# Altium schematic sheet Motor Driver.SchDoc("Motor Driver")
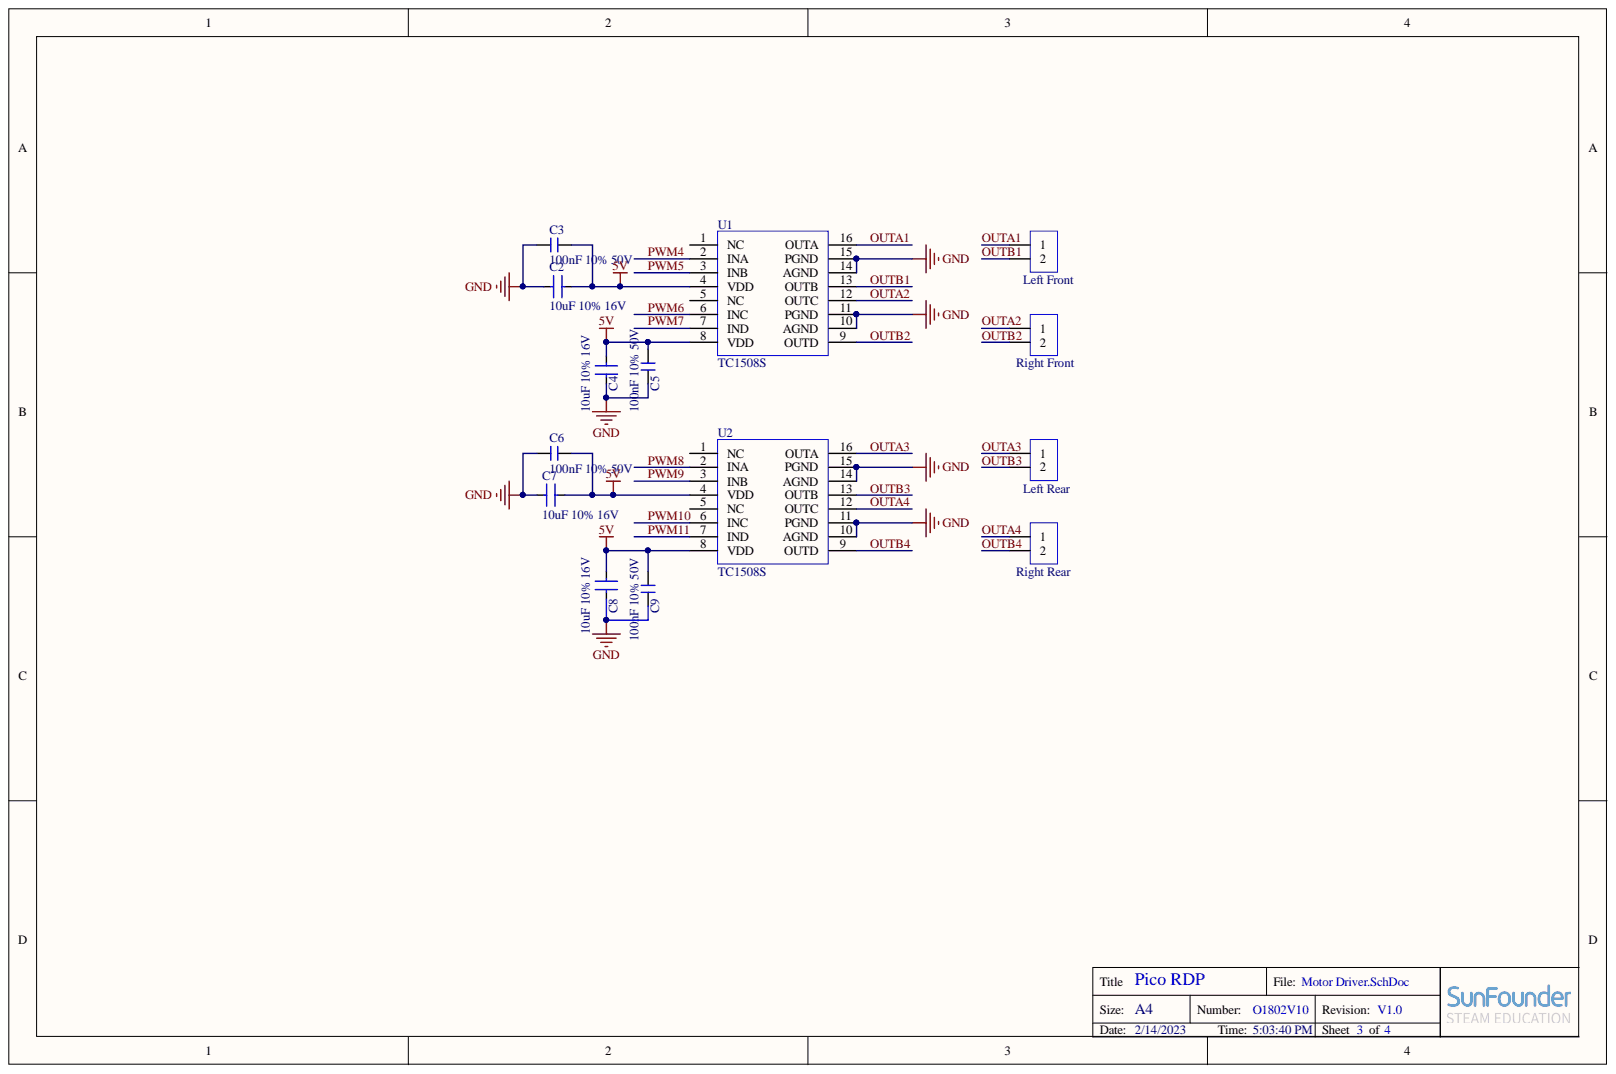
[diagram p0: Motor Driver.SchDoc]

## Parts
C2  10uF 10% 16V  [电容-0805]
C3  100nF 10% 50V  [电容-0603]
C4  10uF 10% 16V  [电容-0805]
C5  100nF 10% 50V  [电容-0603]
C6  100nF 10% 50V  [电容-0603]
C7  10uF 10% 16V  [电容-0805]
C8  10uF 10% 16V  [电容-0805]
C9  100nF 10% 50V  [电容-0603]
Left_Front  XH2.54 2P 直插 立式  [XH2.54 2P 直插 立式]  (value not drawn)
Left_Rear  XH2.54 2P 直插 立式  [XH2.54 2P 直插 立式]  (value not drawn)
Right_Front  XH2.54 2P 直插 立式  [XH2.54 2P 直插 立式]  (value not drawn)
Right_Rear  XH2.54 2P 直插 立式  [XH2.54 2P 直插 立式]  (value not drawn)
U1  TC1508S  [SOP16]
U2  TC1508S  [SOP16]

## Nets
5V: C2.1 C3.1 C4.2 C5.2 C6.1 C7.1 C8.2 C9.2 U1.4(VDD) U1.8(VDD) U2.4(VDD) U2.8(VDD)
GND: C2.2 C3.2 C4.1 C5.1 C6.2 C7.2 C8.1 C9.1 U1.10(AGND) U1.11(PGND) U1.14(AGND) U1.15(PGND) U2.10(AGND) U2.11(PGND) U2.14(AGND) U2.15(PGND)
OUTA1: Left_Front.1 U1.16(OUTA)
OUTA2: Right_Front.1 U1.12(OUTC)
OUTA3: Left_Rear.1 U2.16(OUTA)
OUTA4: Right_Rear.1 U2.12(OUTC)
OUTB1: Left_Front.2 U1.13(OUTB)
OUTB2: Right_Front.2 U1.9(OUTD)
OUTB3: Left_Rear.2 U2.13(OUTB)
OUTB4: Right_Rear.2 U2.9(OUTD)
PWM4: U1.2(INA)
PWM5: U1.3(INB)
PWM6: U1.6(INC)
PWM7: U1.7(IND)
PWM8: U2.2(INA)
PWM9: U2.3(INB)
PWM10: U2.6(INC)
PWM11: U2.7(IND)

Not connected: U1 1(NC) 5(NC); U2 1(NC) 5(NC)

## Library data of the parts
C2 C4 C7 C8 = Cap: 无极性贴片电容; Component Kind: Standard; Library Name: SunFounder_Library.IntLib
C3 C5 C6 C9 = C: 无极性贴片电容; 材质: X7R; 版权归属: 源创客; BOM名称: 无极性贴片电容; Component Kind: Standard; Library Name: ESP32 HAT.SchLib; 学习资源: www.ooocke.com
Left_Front Left_Rear Right_Front Right_Rear = Header 1x2: 2P接插件; 版权归属: 源创客; BOM名称: 2P接插件; Component Kind: Standard; 学习资源: www.ooocke.com; Library Name: SunFounder_Library.IntLib
U1 U2 = TC1508S: 电机驱动H桥; 版权归属: 源创客; BOM名称: 电机驱动H桥; Component Kind: Standard; 学习资源: www.ooocke.com; Library Name: SunFounder_Library.IntLib
